# Altium schematic sheet Steering Wheel Interface Digital Inputs.SchDoc("Steering Wheel Interface Digital Inputs")
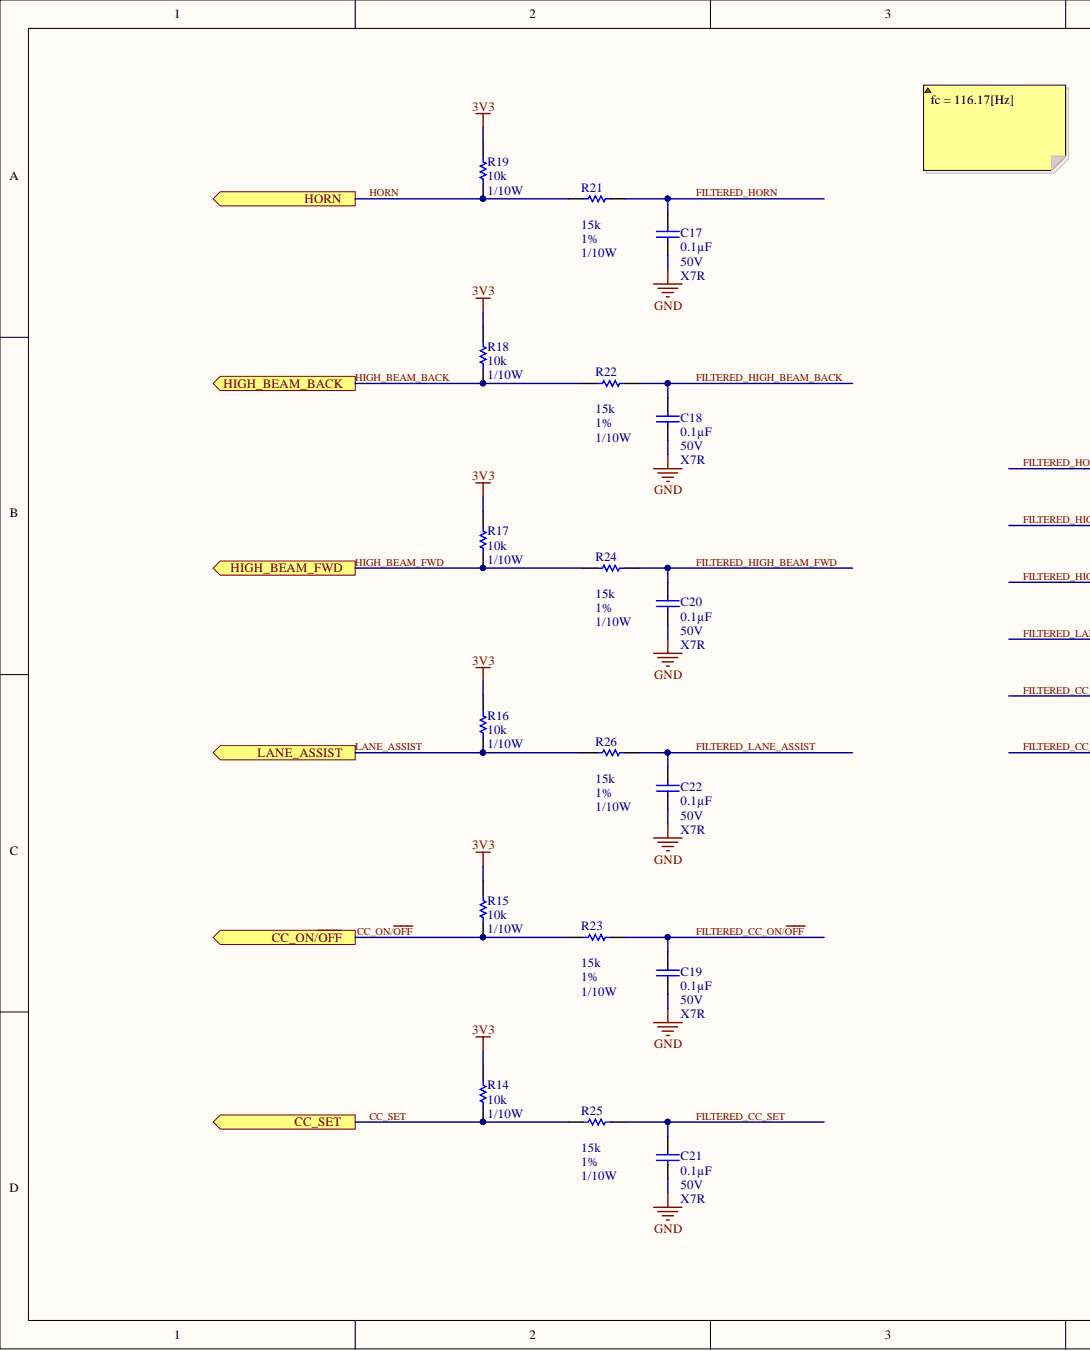
[diagram p0: Steering Wheel Interface Digital Inputs.SchDoc, left]
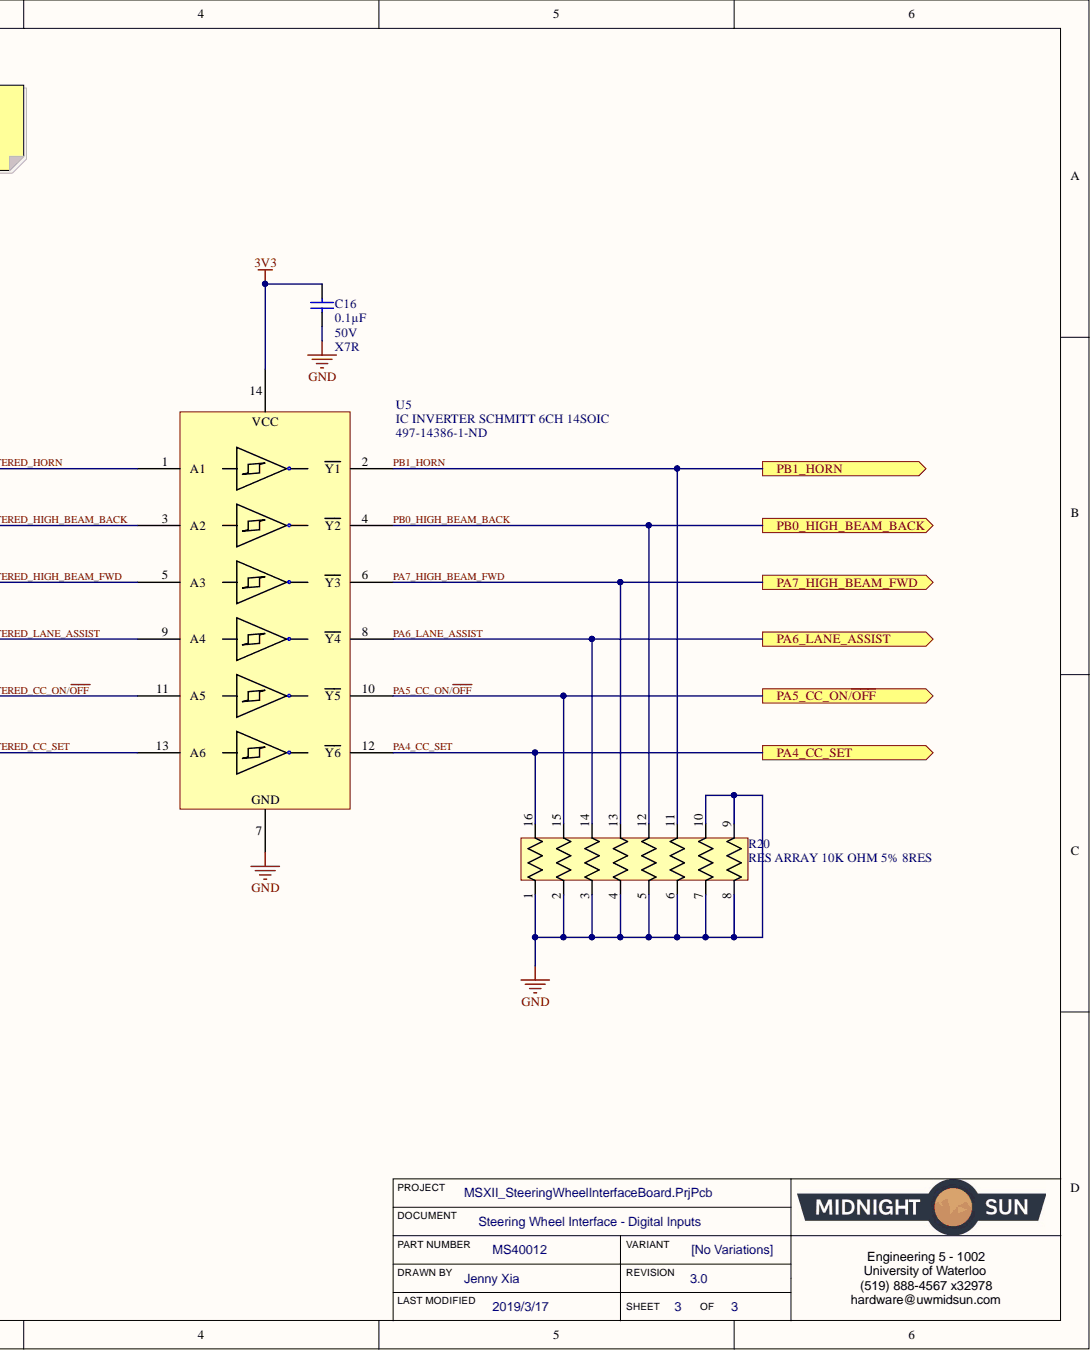
[diagram p1: Steering Wheel Interface Digital Inputs.SchDoc, right]

## Parts
C16  Voltage: 50V  Temperature Coefficient: X7R  Capacitance: 0.1µF  [CAP, 0603]  (hidden comment: CAP CER 0.1UF 50V 10% X7R 0603)
C17  Voltage: 50V  Temperature Coefficient: X7R  Capacitance: 0.1µF  [CAP, 0603]  (hidden comment: CAP CER 0.1UF 50V 10% X7R 0603)
C18  Voltage: 50V  Temperature Coefficient: X7R  Capacitance: 0.1µF  [CAP, 0603]  (hidden comment: CAP CER 0.1UF 50V 10% X7R 0603)
C19  Voltage: 50V  Temperature Coefficient: X7R  Capacitance: 0.1µF  [CAP, 0603]  (hidden comment: CAP CER 0.1UF 50V 10% X7R 0603)
C20  Voltage: 50V  Temperature Coefficient: X7R  Capacitance: 0.1µF  [CAP, 0603]  (hidden comment: CAP CER 0.1UF 50V 10% X7R 0603)
C21  Voltage: 50V  Temperature Coefficient: X7R  Capacitance: 0.1µF  [CAP, 0603]  (hidden comment: CAP CER 0.1UF 50V 10% X7R 0603)
C22  Voltage: 50V  Temperature Coefficient: X7R  Capacitance: 0.1µF  [CAP, 0603]  (hidden comment: CAP CER 0.1UF 50V 10% X7R 0603)
R14  Resistance: 10k  Power: 1/10W  [RES, 0603]  (hidden comment: RES 10K OHM 1% 1/10W 0603)
R15  Resistance: 10k  Power: 1/10W  [RES, 0603]  (hidden comment: RES 10K OHM 1% 1/10W 0603)
R16  Resistance: 10k  Power: 1/10W  [RES, 0603]  (hidden comment: RES 10K OHM 1% 1/10W 0603)
R17  Resistance: 10k  Power: 1/10W  [RES, 0603]  (hidden comment: RES 10K OHM 1% 1/10W 0603)
R18  Resistance: 10k  Power: 1/10W  [RES, 0603]  (hidden comment: RES 10K OHM 1% 1/10W 0603)
R19  Resistance: 10k  Power: 1/10W  [RES, 0603]  (hidden comment: RES 10K OHM 1% 1/10W 0603)
R20  RES ARRAY 10K OHM 5% 8RES  [RES ARRAY, 8 POS 1506]
R21  Resistance: 15k  [RES, 0603]  (hidden comment: RES SMD 15K OHM 1% 1/10W 0603)
R22  Tolerance: 1%  Resistance: 15k  [RES, 0603]  (hidden comment: RES SMD 15K OHM 1% 1/10W 0603)
R23  Tolerance: 1%  Resistance: 15k  [RES, 0603]  (hidden comment: RES SMD 15K OHM 1% 1/10W 0603)
R24  Tolerance: 1%  Resistance: 15k  [RES, 0603]  (hidden comment: RES SMD 15K OHM 1% 1/10W 0603)
R25  Tolerance: 1%  Resistance: 15k  [RES, 0603]  (hidden comment: RES SMD 15K OHM 1% 1/10W 0603)
R26  Tolerance: 1%  Resistance: 15k  [RES, 0603]  (hidden comment: RES SMD 15K OHM 1% 1/10W 0603)
U5  IC INVERTER SCHMITT 6CH 14SOIC  Supplier Part Number 1: 497-14386-1-ND  [IC INVERTER SCHMITT 6CH 14TSSOP]

## Ports
CC_ON/~{OFF}, CC_SET, HIGH_BEAM_BACK, HIGH_BEAM_FWD, HORN, LANE_ASSIST, PA4_CC_SET, PA5_CC_ON/~{OFF}, PA6_LANE_ASSIST, PA7_HIGH_BEAM_FWD, PB0_HIGH_BEAM_BACK, PB1_HORN

## Nets
3V3: C16.1 R14.1 R15.1 R16.1 R17.1 R18.1 R19.1 U5.14
GND: C16.2 C17.2 C18.2 C19.2 C20.2 C21.2 C22.2 R20.1 R20.2 R20.3 R20.4 R20.5 R20.6 R20.7 R20.8 R20.9 R20.10 U5.7
CC_ON/~{OFF}: R15.2 R23.2 ; port CC_ON/~{OFF}
CC_SET: R14.2 R25.2 ; port CC_SET
FILTERED_CC_ON/~{OFF}: C19.1 R23.1 U5.11(A5)
FILTERED_CC_SET: C21.1 R25.1 U5.13(A6)
FILTERED_HIGH_BEAM_BACK: C18.1 R22.1 U5.3(A2)
FILTERED_HIGH_BEAM_FWD: C20.1 R24.1 U5.5(A3)
FILTERED_HORN: C17.1 R21.1 U5.1(A1)
FILTERED_LANE_ASSIST: C22.1 R26.1 U5.9(A4)
HIGH_BEAM_BACK: R18.2 R22.2 ; port HIGH_BEAM_BACK
HIGH_BEAM_FWD: R17.2 R24.2 ; port HIGH_BEAM_FWD
HORN: R19.2 R21.2 ; port HORN
LANE_ASSIST: R16.2 R26.2 ; port LANE_ASSIST
PA4_CC_SET: R20.16 U5.12(Y6) ; port PA4_CC_SET
PA5_CC_ON/~{OFF}: R20.15 U5.10(Y5) ; port PA5_CC_ON/~{OFF}
PA6_LANE_ASSIST: R20.14 U5.8(Y4) ; port PA6_LANE_ASSIST
PA7_HIGH_BEAM_FWD: R20.13 U5.6(Y3) ; port PA7_HIGH_BEAM_FWD
PB0_HIGH_BEAM_BACK: R20.12 U5.4(Y2) ; port PB0_HIGH_BEAM_BACK
PB1_HORN: R20.11 U5.2(Y1) ; port PB1_HORN

## Library data of the parts
C16-C22 = CAP CER 0.1UF 50V 10% X7R 0603: 0.10µF ±10% 50V Ceramic Capacitor X7R 0603 (1608 Metric); Manufacturer Part Number 1: 06035C104KAT2A; Manufacturer 1: AVX Corporation; Tolerance: ±10%; Thickness (Max): 0.035" (0.90mm); Supplier Part Number 1: 478-5052-1-ND; Supplier 1: Digi-Key; Size / Dimension: 0.063" L x 0.032" W (1.60mm x 0.81mm); Ratings: -; Part Status: Active; Packaging: Cut Tape (CT); Package / Case: 0603 (1608 Metric); Operating Temperature: -55°C ~ 125°C; Mounting Type: Surface Mount, MLCC; Lead Style: -; Lead Spacing: -; Height - Seated (Max): -; ComponentLink1URL: http://datasheets.avx.com/X7RDielectric.pdf; ComponentLink1Description: http://datasheets.avx.com/X7RDielectric.pdf; Component Kind: Standard; Library Name: Schematic Diagrams.SchLib
R14-R19 = RES 10K OHM 1% 1/10W 0603: RES SMD 10K OHM 1% 1/10W 0603; Manufacturer Part Number 1: RC0603FR-0710KL; Manufacturer 1: Yageo; Temperature Coefficient: ±100ppm/°C; Tolerance: ±1%; Supplier Part Number 1: 311-10.0KHRCT-ND; Supplier Device Package: 0603; Supplier 1: Digi-Key; Size / Dimension: 0.063" L x 0.031" W (1.60mm x 0.80mm); Part Status: Active; Packaging: Cut Tape (CT); Package / Case: 0603 (1608 Metric); Operating Temperature: -55°C ~ 155°C; Height - Seated (Max): 0.022" (0.55mm); Failure Rate: -; Composition: Thick Film; ComponentLink1URL: http://www.yageo.com/documents/recent/PYu-RC_Group_51_RoHS_L_7.pdf; ComponentLink1Description: http://www.yageo.com/documents/recent/PYu-RC_Group_51_RoHS_L_7.pdf; Component Kind: Standard; Library Name: Schematic Diagrams.SchLib
R20 = RES ARRAY 10K OHM 5% 8RES EXB-2HV103JV: RES ARRAY SMD 10K 5% 62.5mW 1506; Manufacturer: Panasonic Electronic Components; Vendor: Panasonic; Temperature Coefficient: ±200ppm/°C; Circuit Type: Isolated; C: Copyright (C) 2015 Accelerated Designs. All rights reserved; Value: Value; Tolerance: ±5%; Supplier Part Number 1: Y1103CT-ND; Supplier Device Package: 1506; Supplier 1: Digi-Key; Stock 1: 152779; Size / Dimension: 0.150" L x 0.063" W (3.80mm x 1.60mm); RoHS: RoHS Compliant; Resistance (Ohms): 10k; Pricing 1: 1=0.43, 10=0.373, 25=0.2712, 50=0.1964, 100=0.1456, 250=0.11176, 500=0.08806, 1000=0.06096 (CAD); Power Per Element: 62.5mW; Part Status: Active; Packaging: Cut Tape (CT); Package / Case: 1506, Convex, Long Side Terminals; Operating Temperature: -55°C ~ 125°C; Mounting Type: Surface Mount; Manufacturer Part Number: EXB-2HV103JV; Manufacturer_Part_Number: EXB-2HV103JV; Height - Seated (Max): 0.022" (0.55mm); ComponentLink1URL: https://industrial.panasonic.com/cdbs/www-data/pdf/AOC0000/AOC0000C14.pdf; ComponentLink1Description: https://industrial.panasonic.com/cdbs/www-data/pdf/AOC0000/AOC0000C14.pdf; Component Kind: Standard; Category: Resistors; Applications: Automotive AEC-Q200; Library Name: Schematic Diagrams.SchLib; Copyright: Copyright (C) 2015 Accelerated Designs. All rights reserved
R21-R26 = RES SMD 15K OHM 1% 1/10W 0603: 15 kOhms ±1% 0.1W, 1/10W Chip Resistor 0603 (1608 Metric) Moisture Resistant Thick Film; Manufacturer Part Number: RC0603FR-0715KL; Manufacturer: Yageo; Voltage Rating (DC): 50V; Temperature Coefficient: 100ppm/°C; Power Rating: 100mW; Width: 0.031inch; Voltage Rating: 50V; Termination: SMD/SMT; Supplier Part Number 1: 311-15.0KHRCT-ND; Supplier 1: Digi-Key; Stock 1: 8611420; RoHS: Yes; REACH SVHC: Unknown; Pricing 1: 1 = 0.10000, 10 = 0.02400, 100 = 0.00970, 1000 = 0.00437, 2500 = 0.00379 (USD); Packaging: Tape and Reel; Package Quantity: 1; Number of Terminations: 2; Number of Pins: 2; Min Operating Temperature: -55°C; Military Standard: Not; Max Power Dissipation: 1/10W; Max Operating Temperature: 155°C; Length: 1.6mm; Lead Free: Lead Free; Height: 0.022inch; Features: Moisture Resistant; Depth: 0.8mm; Composition: Thick Film; ComponentLink4URL: https://www.verical.com/server-webapp/html/getDatasheet?partID=327895; ComponentLink4Description: https://www.verical.com/server-webapp/html/getDatasheet?partID=327895; ComponentLink3URL: http://www.yageo.com/documents/recent/PYu-RC_Group_51_RoHS_L_9.pdf; ComponentLink3Description: http://www.yageo.com/documents/recent/PYu-RC_Group_51_RoHS_L_9.pdf; ComponentLink2URL: http://www.yageo.com/documents/recent/PYu-RC_Group_51_RoHS_L_10.pdf; ComponentLink2Description: http://www.yageo.com/documents/recent/PYu-RC_Group_51_RoHS_L_10.pdf; ComponentLink1URL: https://www.digikey.ca/product-detail/en/yageo/RC0603FR-0715KL/311-15.0KHRCT-ND/729900; ComponentLink1Description: Digikey; Component Kind: Standard; Case Code (Metric): 1608; Case Code (Imperial): 0603; Case/Package: 0603; Library Name: Schematic Diagrams.SchLib
  R21: Tolerance: 1%
U5 = IC INVERTER SCHMITT 6CH 14TSSOP: Inverter IC 6 Channel Schmitt Trigger 14-TSSOP; Manufacturer Part Number: M74HC14YRM13TR; Manufacturer: STMicroelectronics; Turn-On Delay Time: 190ns; Quiescent Current: 1uA; Propagation Delay: 21ns; Number of Inputs: 6; Min Supply Voltage: 2V; Max Supply Voltage: 6V; Low Level Output Current: 5.2mA; High Level Output Current: -5.2mA; Weight: 0.009143oz; Supplier 1: Digi-Key; Stock 1: 28888; RoHS: Yes; Pricing 1: 1 = 0.48000, 10 = 0.41300, 100 = 0.30860, 500 = 0.24246, 1000 = 0.18736 (USD); Packaging: Tape and Reel; Package Quantity: 2500; Number of Pins: 14; Number of Elements: 6; Number of Circuits: 6; Mount: Surface Mount; Min Operating Temperature: -40°C; Max Operating Temperature: 125°C; Logic Function: Inverter; ComponentLink5URL: http://www.st.com/st-web-ui/static/active/en/resource/technical/document/datasheet/CD00000263.pdf?src-supplier=Avnet; ComponentLink5Description: http://www.st.com/st-web-ui/static/active/en/resource/technical/document/datasheet/CD00000263.pdf?src-supplier=Avnet; ComponentLink4URL: http://www.st.com/content/ccc/resource/technical/document/datasheet/19/a3/52/42/dd/ab/4b/46/CD00000263.pdf/files/CD00000263.pdf/jcr:content/translations/en.CD00000263.pdf?src-supplier=Digi-Key; ComponentLink4Description: http://www.st.com/content/ccc/resource/technical/document/datasheet/19/a3/52/42/dd/ab/4b/46/CD00000263.pdf/files/CD00000263.pdf/jcr:content/translations/en.CD00000263.pdf?src-supplier=Digi-Key; ComponentLink3URL: http://www.st.com/st-web-ui/static/active/en/resource/technical/document/datasheet/CD00000263.pdf; ComponentLink3Description: http://www.st.com/st-web-ui/static/active/en/resource/technical/document/datasheet/CD00000263.pdf; ComponentLink2URL: http://www.st.com/content/ccc/resource/technical/document/datasheet/19/a3/52/42/dd/ab/4b/46/CD00000263.pdf/files/CD00000263.pdf/jcr:content/translations/en.CD00000263.pdf; ComponentLink2Description: http://www.st.com/content/ccc/resource/technical/document/datasheet/19/a3/52/42/dd/ab/4b/46/CD00000263.pdf/files/CD00000263.pdf/jcr:content/translations/en.CD00000263.pdf; ComponentLink1URL: https://www.digikey.ca/product-detail/en/stmicroelectronics/M74HC14YTTR/497-14387-1-ND/4725844; ComponentLink1Description: Digikey; Component Kind: Standard; Case/Package: SO; Library Name: Schematic Diagrams.SchLib
